# Altium schematic sheet Nav_Solenoid_IO.SchDoc
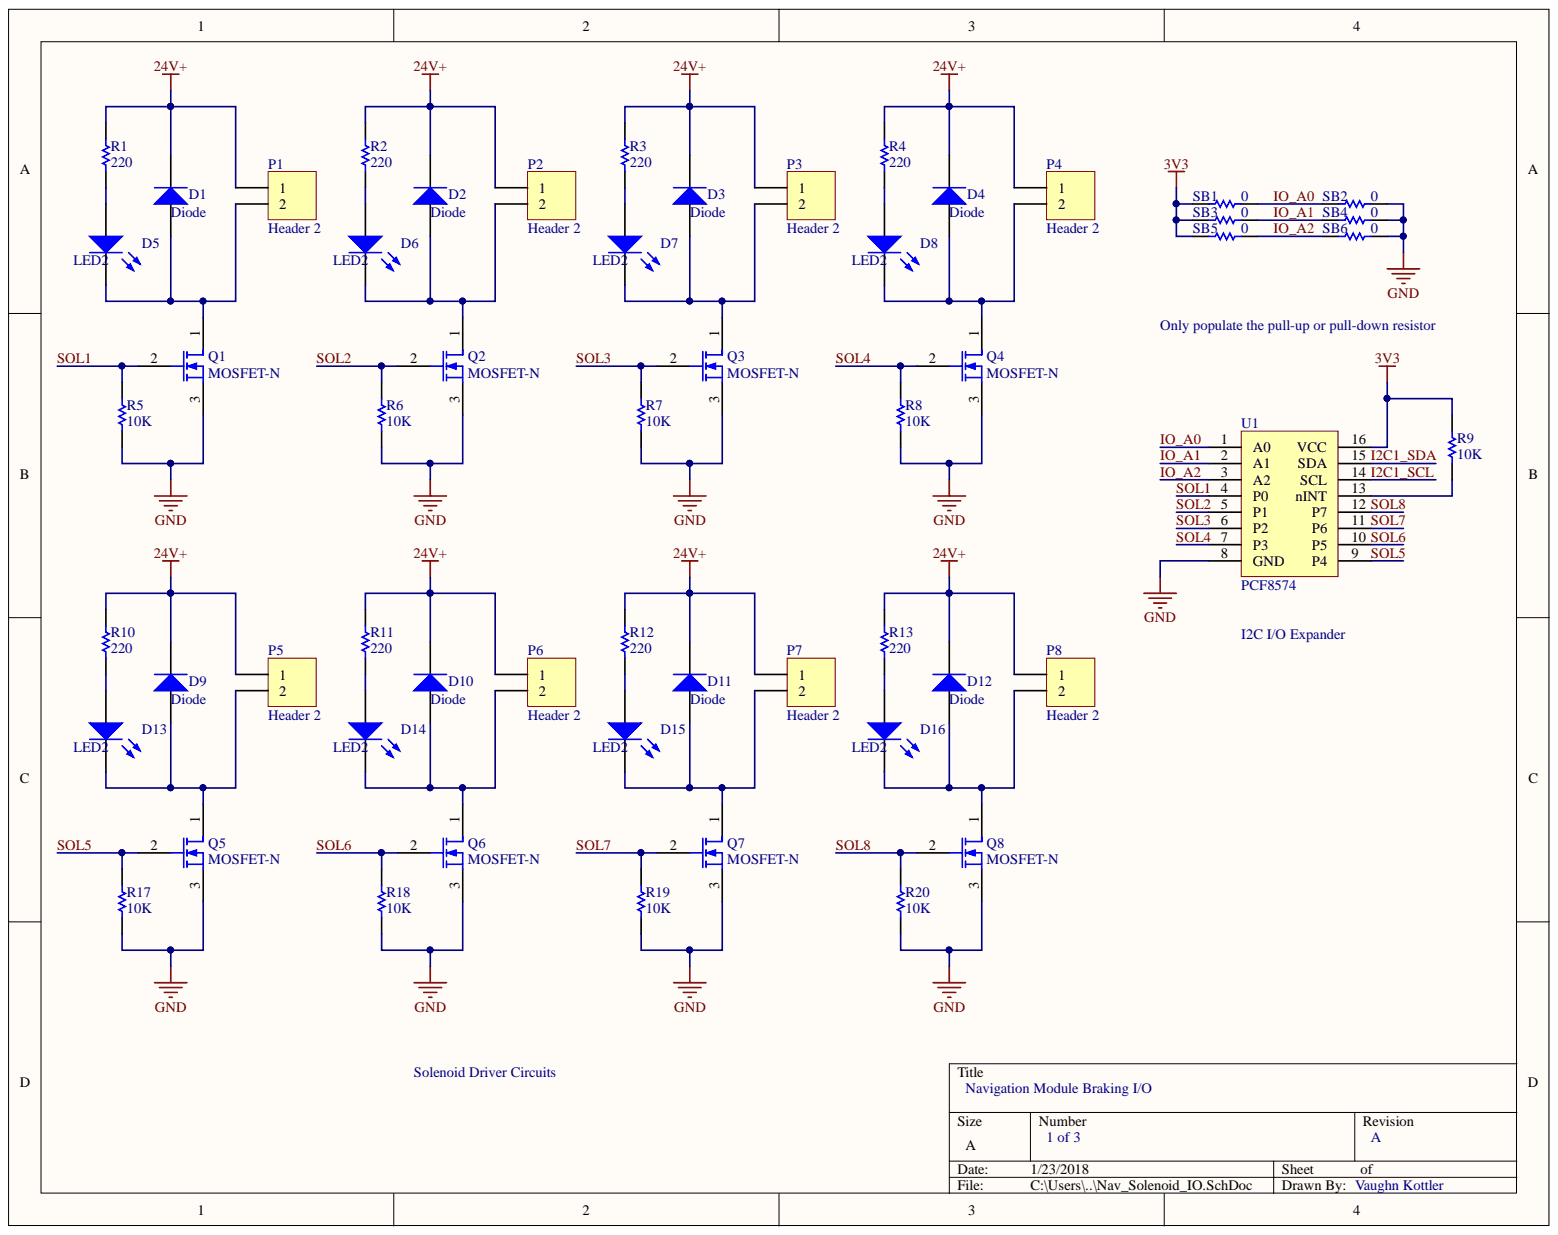
[diagram p0: Nav_Solenoid_IO.SchDoc]

## Parts
D1  Diode  [DIODE_SMC]
D2  Diode  [DIODE_SMC]
D3  Diode  [DIODE_SMC]
D4  Diode  [DIODE_SMC]
D5  LED2  [3.2X1.6X1.1]
D6  LED2  [3.2X1.6X1.1]
D7  LED2  [3.2X1.6X1.1]
D8  LED2  [3.2X1.6X1.1]
D9  Diode  [DIODE_SMC]
D10  Diode  [DIODE_SMC]
D11  Diode  [DIODE_SMC]
D12  Diode  [DIODE_SMC]
D13  LED2  [3.2X1.6X1.1]
D14  LED2  [3.2X1.6X1.1]
D15  LED2  [3.2X1.6X1.1]
D16  LED2  [3.2X1.6X1.1]
P1  Header 2  [2POS TERM BLOCK]
P2  Header 2  [2POS TERM BLOCK]
P3  Header 2  [2POS TERM BLOCK]
P4  Header 2  [2POS TERM BLOCK]
P5  Header 2  [2POS TERM BLOCK]
P6  Header 2  [2POS TERM BLOCK]
P7  Header 2  [2POS TERM BLOCK]
P8  Header 2  [2POS TERM BLOCK]
Q1  MOSFET-N  [SOT-23_N]
Q2  MOSFET-N  [SOT-23_N]
Q3  MOSFET-N  [SOT-23_N]
Q4  MOSFET-N  [SOT-23_N]
Q5  MOSFET-N  [SOT-23_N]
Q6  MOSFET-N  [SOT-23_N]
Q7  MOSFET-N  [SOT-23_N]
Q8  MOSFET-N  [SOT-23_N]
R1  value 220  [6-0805_M]  (hidden comment: Res1)
R2  value 220  [6-0805_M]  (hidden comment: Res1)
R3  value 220  [6-0805_M]  (hidden comment: Res1)
R4  value 220  [6-0805_M]  (hidden comment: Res1)
R5  value 10K  [6-0805_M]  (hidden comment: Res1)
R6  value 10K  [6-0805_M]  (hidden comment: Res1)
R7  value 10K  [6-0805_M]  (hidden comment: Res1)
R8  value 10K  [6-0805_M]  (hidden comment: Res1)
R9  value 10K  [6-0805_M]  (hidden comment: Res1)
R10  value 220  [6-0805_M]  (hidden comment: Res1)
R11  value 220  [6-0805_M]  (hidden comment: Res1)
R12  value 220  [6-0805_M]  (hidden comment: Res1)
R13  value 220  [6-0805_M]  (hidden comment: Res1)
R17  value 10K  [6-0805_M]  (hidden comment: Res1)
R18  value 10K  [6-0805_M]  (hidden comment: Res1)
R19  value 10K  [6-0805_M]  (hidden comment: Res1)
R20  value 10K  [6-0805_M]  (hidden comment: Res1)
SB1  value 0  [6-0805_M]  (hidden comment: Res1)
SB2  value 0  [6-0805_M]  (hidden comment: Res1)
SB3  value 0  [6-0805_M]  (hidden comment: Res1)
SB4  value 0  [6-0805_M]  (hidden comment: Res1)
SB5  value 0  [6-0805_M]  (hidden comment: Res1)
SB6  value 0  [6-0805_M]  (hidden comment: Res1)
U1  PCF8574  [16-SOIC]

## Nets
3V3: R9.2 SB1.2 SB3.2 SB5.2 U1.16(VCC)
24V+: D1.2 D2.2 D3.2 D4.2 D9.2 D10.2 D11.2 D12.2 P1.1 P2.1 P3.1 P4.1 P5.1 P6.1 P7.1 P8.1 R1.2 R2.2 R3.2 R4.2 R10.2 R11.2 R12.2 R13.2
GND: Q1.3 Q2.3 Q3.3 Q4.3 Q5.3 Q6.3 Q7.3 Q8.3 R5.1 R6.1 R7.1 R8.1 R17.1 R18.1 R19.1 R20.1 SB2.1 SB4.1 SB6.1 U1.8(GND)
I2C1_SCL: U1.14(SCL)
I2C1_SDA: U1.15(SDA)
IO_A0: SB1.1 SB2.2 U1.1(A0)
IO_A1: SB3.1 SB4.2 U1.2(A1)
IO_A2: SB5.1 SB6.2 U1.3(A2)
SOL1: Q1.2 R5.2 U1.4(P0)
SOL2: Q2.2 R6.2 U1.5(P1)
SOL3: Q3.2 R7.2 U1.6(P2)
SOL4: Q4.2 R8.2 U1.7(P3)
SOL5: Q5.2 R17.2 U1.9(P4)
SOL6: Q6.2 R18.2 U1.10(P5)
SOL7: Q7.2 R19.2 U1.11(P6)
SOL8: Q8.2 R20.2 U1.12(P7)
NetD1_1: D1.1 D5.2 P1.2 Q1.1
NetD2_1: D2.1 D6.2 P2.2 Q2.1
NetD3_1: D3.1 D7.2 P3.2 Q3.1
NetD4_1: D4.1 D8.2 P4.2 Q4.1
NetD5_1: D5.1 R1.1
NetD6_1: D6.1 R2.1
NetD7_1: D7.1 R3.1
NetD8_1: D8.1 R4.1
NetD9_1: D9.1 D13.2 P5.2 Q5.1
NetD10_1: D10.1 D14.2 P6.2 Q6.1
NetD11_1: D11.1 D15.2 P7.2 Q7.1
NetD12_1: D12.1 D16.2 P8.2 Q8.1
NetD13_1: D13.1 R10.1
NetD14_1: D14.1 R11.1
NetD15_1: D15.1 R12.1
NetD16_1: D16.1 R13.1
NetR9_1: R9.1 U1.13(nINT)

## Library data of the parts
D1-D4 D9-D12 = Diode: Default Diode; Code_JEDEC: DO-214-AB; Component Kind: Standard; LatestRevisionDate: 17-Jul-2002; LatestRevisionNote: Re-released for DXP Platform.; Library Name: Miscellaneous Devices.IntLib; PackageDescription: DO-214-AB/SMC; 2 C-Bend Leads; Body 7.9 x 5.9 mm, inc. leads (LxW); PackageReference: SMC; PackageVersion: Sep-1996; Published: 8-Jun-2000; Signal Integrity: Diode; Simulation: DIODE; Publisher: Altium Limited
D5-D8 D13-D16 = LED2: Typical RED, GREEN, YELLOW, AMBER GaAs LED; Component Kind: Standard; LatestRevisionDate: 17-Jul-2002; LatestRevisionNote: Re-released for DXP Platform.; Library Name: Miscellaneous Devices.IntLib; PackageDescription: SM LED; 2 Flat Leads; PackageReference: 3.2X1.6X1.1; PackageVersion: Feb-2002; Published: 8-Jun-2000; Simulation: LED2; Publisher: Altium Limited
P1-P8 = Header 2: Header, 2-Pin; Component Kind: Standard; LatestRevisionDate: 17-Jul-2002; LatestRevisionNote: Re-released for DXP Platform.; Library Name: Miscellaneous Connectors.IntLib; Signal Integrity: Connector; Publisher: Altium Limited
Q1-Q8 = MOSFET-N: N-Channel MOSFET; Component Kind: Standard; LatestRevisionDate: 17-Jul-2002; LatestRevisionNote: Re-released for DXP Platform.; Library Name: Miscellaneous Devices.IntLib; PackageDescription: TO, Flat Index; 3 In-Line, Axial Leads; Body Dia. 4.6mm; Lead Dia. 0.5 mm (max); PackageReference: E3; PackageVersion: 1995/1996; Published: 8-Jun-2000; Simulation: NMOS; Publisher: Altium Limited
R1-R13 R17-R20 SB1-SB6 = Res1: Resistor; Component Kind: Standard; LatestRevisionDate: 17-Jul-2002; LatestRevisionNote: Re-released for DXP Platform.; Library Name: Miscellaneous Devices.IntLib; PackageDescription: Axial Device, Thru-Hole; 2 Leads; 0.3 in Pin Spacing; PackageReference: AXIAL-0.3; Published: 8-Jun-2000; Signal Integrity: Res; Simulation: RESISTOR; Publisher: Altium Limited
U1 = PCF8574: I2C I/O Expander; Component Kind: Standard; ComponentLink1Description: Part; ComponentLink1URL: https://www.digikey.com/product-detail/en/texas-instruments/PCF8574DWR/296-13108-1-ND/484579; ComponentLink2Description: Datasheet; ComponentLink2URL: http://www.ti.com/lit/ds/symlink/pcf8574.pdf; Library Name: ic.SchLib
